# Altium schematic sheet E.ELI_Power.SchDoc
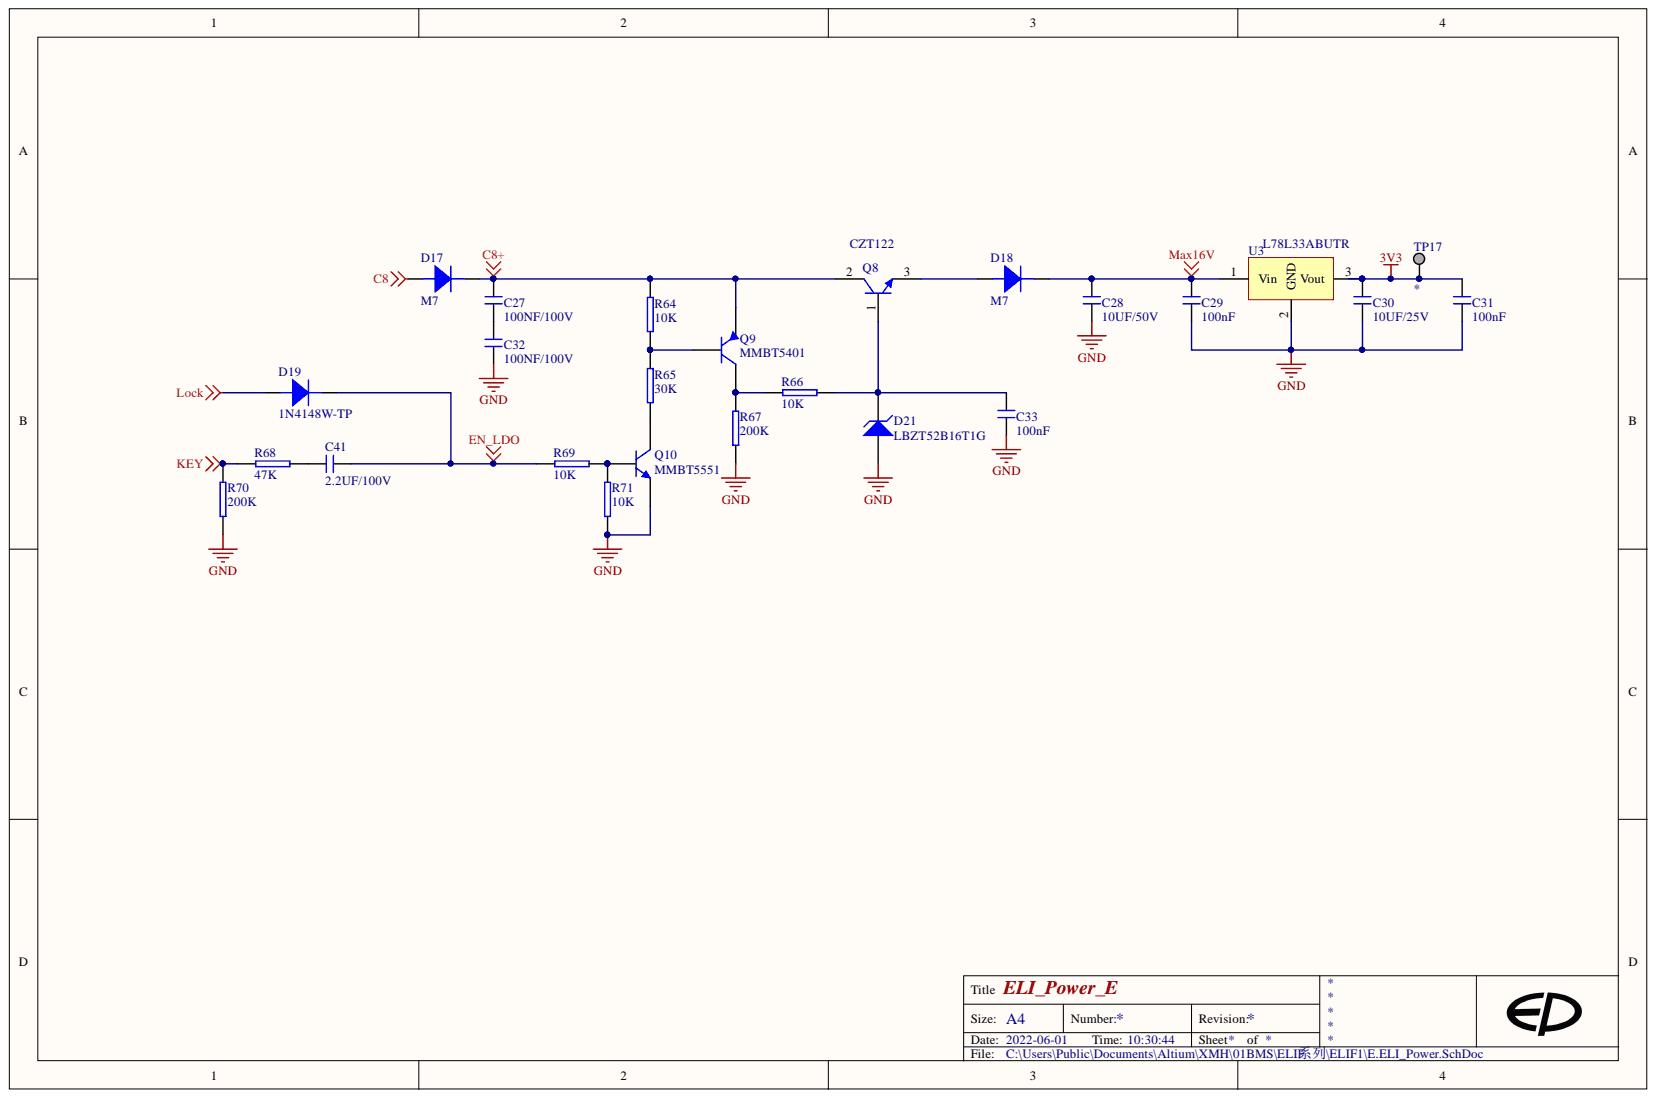
[diagram p0: E.ELI_Power.SchDoc]

## Parts
C27  100NF/100V  [0805-C]
C28  10UF/50V  [1206 -C]
C29  100nF  [0603-C]
C30  10UF/25V  [1206 -C]
C31  100nF  [0603-C]
C32  100NF/100V  [0805-C]
C33  100nF  [0603-C]
C41  2.2UF/100V  [1206 -C]
D17  M7  [SMA_C]
D18  M7  [SMA_C]
D19  1N4148W-TP  [SOD-123]
D21  LBZT52B16T1G  [SOD-123]
Q8  CZT122  [SOT-223 -B2]
Q9  MMBT5401  [SOT-23M]
Q10  MMBT5551  [SOT-23M]
R64  10K  [0603-R]
R65  30K  [0805S]
R66  10K  [1206 -R]
R67  200K  [0603-R]
R68  47K  [0603-R]
R69  10K  [0603-R]
R70  200K  [0603-R]
R71  10K  [0603-R]
TP17  (no value)  [TESTPOINT40]
U3  L78L33ABUTR  [SOT-89-S]

## Nets
3V3: C30.2 C31.2 TP17.1 U3.3
C8: D17.2
C8+: C27.2 D17.1 Q8.2 Q9.2 R64.1
EN_LDO: C41.1 D19.1 R69.2
GND: C28.1 C29.1 C30.1 C31.1 C32.1 C33.1 D21.2 Q10.2 R67.2 R70.2 R71.2 U3.2
KEY: R68.2 R70.1
Lock: D19.2
Max16V: C28.2 C29.2 D18.1 U3.1
NetC27_1: C27.1 C32.2
NetC33_2: C33.2 D21.1 Q8.1 R66.2
NetC41_2: C41.2 R68.1
NetD18_2: D18.2 Q8.3
NetQ9_1: Q9.1 R64.2 R65.1
NetQ9_3: Q9.3 R66.1 R67.1
NetQ10_1: Q10.1 R69.1 R71.1
NetQ10_3: Q10.3 R65.2

## Library data of the parts
C27-C33 C41 = Cap: 贴片电容; Component Kind: Standard; Library Name: xmh_c.IntLib
  C27 C32: Value: 100NF/100V
  C28: Value: 10UF/50V
  C29 C31 C33: Value: 100nF
  C30: Value: 10UF/25V
  C41: Value: 2.2UF/100V
D17 D18 = DIODE: 贴片二极管; Component Kind: Standard; Value: M7; Library Name: xmh_c.IntLib
D19 = DIODE: 二极管; Component Kind: Standard; Value: 1N4148W-TP; Library Name: xmh_c.IntLib
D21 = DIODE_W: Component Kind: Standard; Value: LBZT52B16T1G; Library Name: xmh_c.IntLib
Q8 = NPN_B: 贴片三极管; Component Kind: Standard; Value: CZT122; Library Name: xmh_c.IntLib
Q9 = PNP: 贴片三极管; Component Kind: Standard; Value: MMBT5401; Library Name: xmh_c.IntLib
Q10 = NPN: Component Kind: Standard; Value: MMBT5551; Library Name: xmh_c.IntLib
R64 R65 R67-R71 = RES T: 电阻; Component Kind: Standard; Library Name: xmh_c.IntLib
  R64 R69 R71: Value: 10K
  R65: Value: 30K
  R67 R70: Value: 200K
  R68: Value: 47K
R66 = RES T: 贴片电阻; Component Kind: Standard; Value: 10K; Library Name: xmh_c.IntLib
TP17 = TESTPOINT: TEST POINT.  No entry in BOM.; Component Kind: Standard; Library Name: xmh_c.IntLib
U3 = 78XX: LDO; Parameter 1: LM7805CT/NOPB; Parameter 3: L78L05ABUTR; Parameter 4: L78M05ABDT-TR; Parameter 5: L7805ABD2T-TR; Component Kind: Standard; Parameter 2: L78L33ABUTR; Value: L78L33ABUTR; Library Name: xmh_c.SchLib
